# Altium schematic sheet led_matrix_board.SchDoc(led_matrix_board)
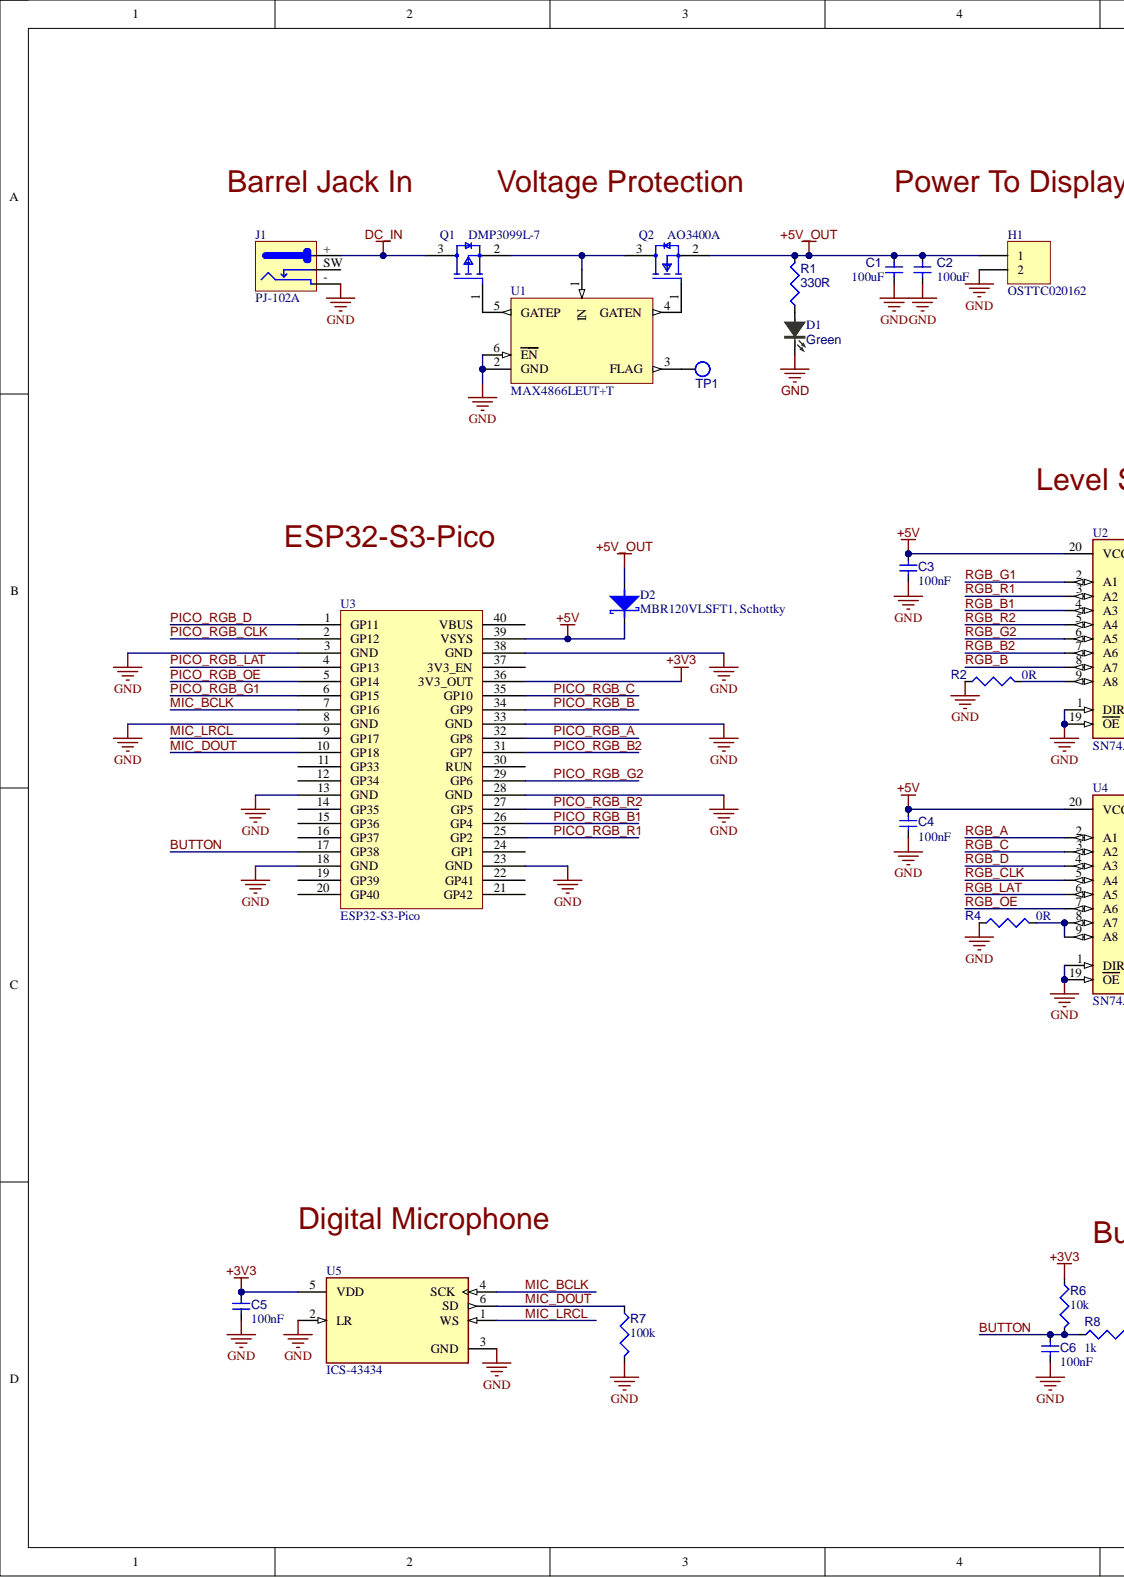
[diagram p0: led_matrix_board.SchDoc, left]
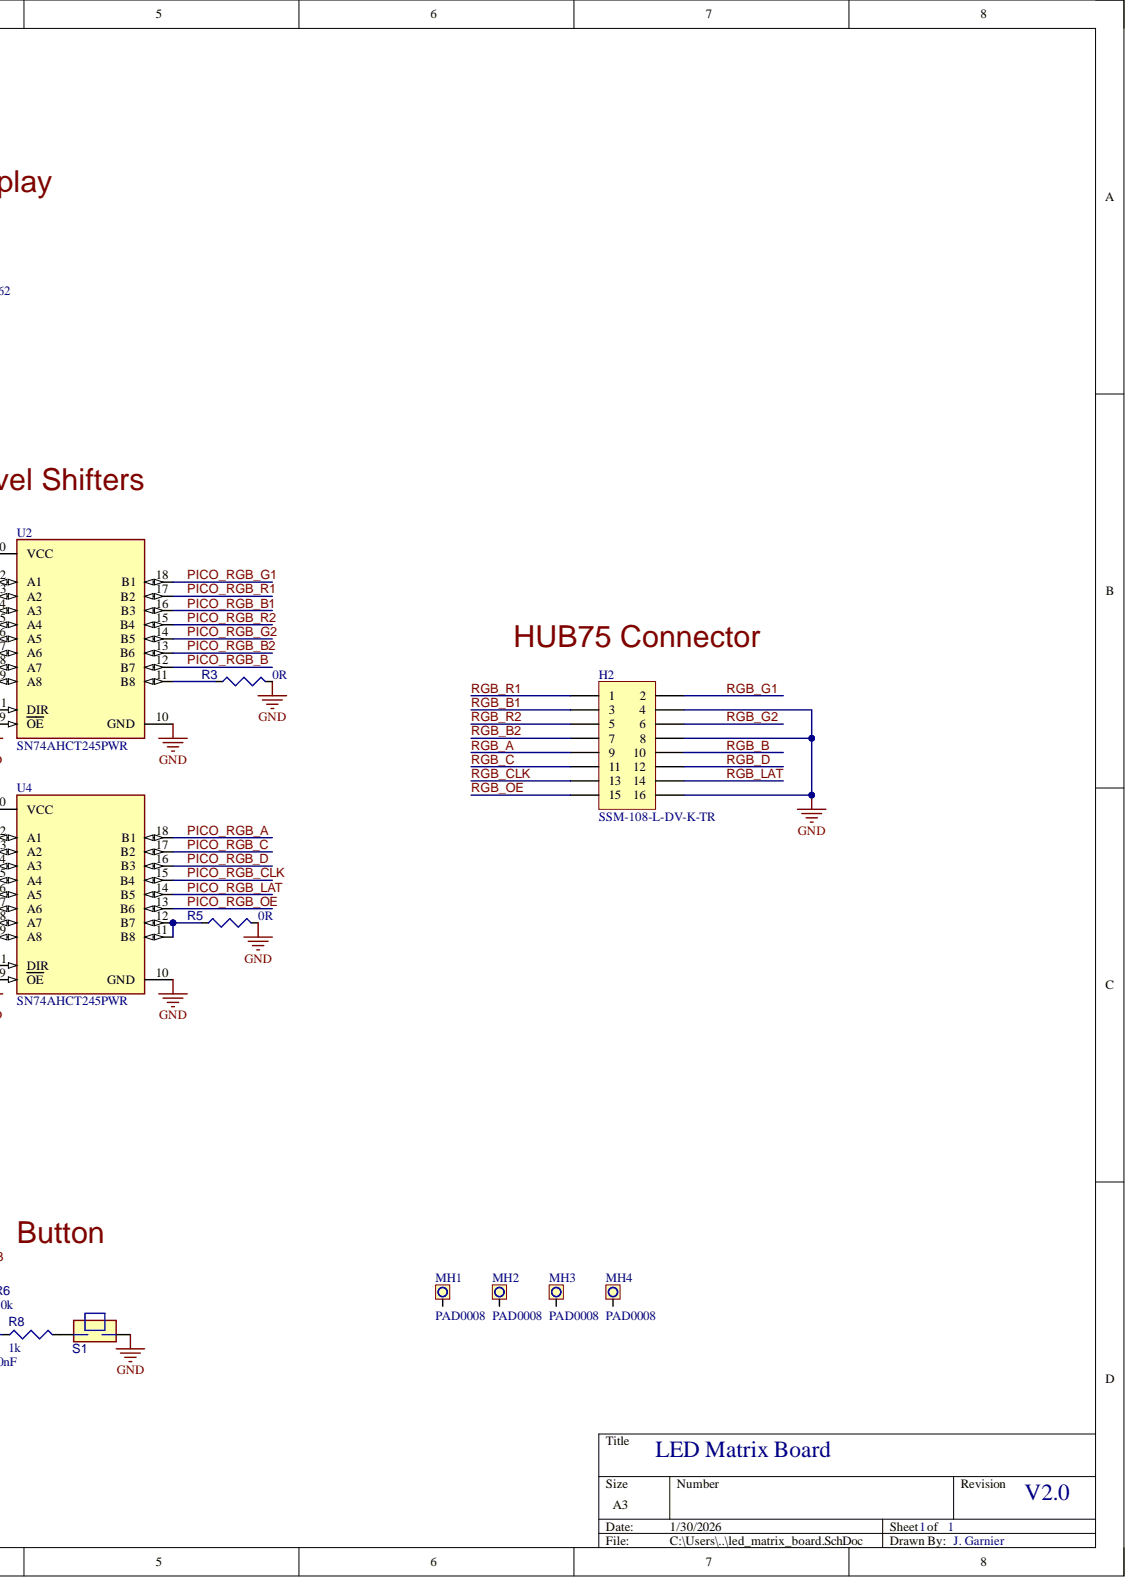
[diagram p1: led_matrix_board.SchDoc, right]

## Parts
C1  value 100uF  [CAPC3225X20N]  (hidden comment: CL32A107MPVNNNE)
C2  value 100uF  [CAPC3225X20N]  (hidden comment: CL32A107MPVNNNE)
C3  value 100nF  [0603_cap]  (hidden comment: C0603C104K8RACTU)
C4  value 100nF  [0603_cap]  (hidden comment: C0603C104K8RACTU)
C5  value 100nF  [0603_cap]  (hidden comment: C0603C104K8RACTU)
C6  value 100nF  [0603_cap]  (hidden comment: C0603C104K8RACTU)
D1  value Green  [LED_0402_GREEN]  (hidden comment: XZVGR68W-3)
D2  MBR120VLSFT1  value MBR120VLSFT1, Schottky  [SOD-123FL]
H1  OSTTC020162  [SCREW_TERM_2WAY_5MM]
H2  SSM-108-L-DV-K-TR  [FP-SSM-108-L-DV-K-TR-MFG]
J1  PJ-102A  [PJ-102A]
MH1  PAD0008  [MH_600_320_NPTH]
MH2  PAD0008  [MH_600_320_NPTH]
MH3  PAD0008  [MH_600_320_NPTH]
MH4  PAD0008  [MH_600_320_NPTH]
Q1  DMP3099L-7  [FP-SOT23-IPC_C]
Q2  AO3400A  [FP-SOT23-IPC_C]
R1  value 330R  [0402_res]  (hidden comment: MCR01MZPF3300)
R2  value 0R  [0603_res]  (hidden comment: ERJ-3GEY0R00V)
R3  value 0R  [0603_res]  (hidden comment: ERJ-3GEY0R00V)
R4  value 0R  [0603_res]  (hidden comment: ERJ-3GEY0R00V)
R5  value 0R  [0603_res]  (hidden comment: ERJ-3GEY0R00V)
R6  value 10k  [0603_res]  (hidden comment: 0603WAF1002T5E)
R7  value 100k  [0603_res]  (hidden comment: 0603WAF1003T5E)
R8  value 1k  [0603_res]  (hidden comment: ERA-3AEB102V)
S1  EVQ-PF206K  [EVQ-PF206K]  (value not drawn)
TP1  PAD0035  [TP_40_SMT]  (value not drawn)  (no BOM)
U1  MAX4866LEUT+T  [MAX4866LEUT+T-Footprint-1]
U2  SN74AHCT245PWR  [FP-PW0020A-IPC_A]
U3  ESP32-S3-Pico  [ESP32-S3-Pico]
U4  SN74AHCT245PWR  [FP-PW0020A-IPC_A]
U5  ICS-43434  [FP-ICS-43434-MFG]

## Nets
+3V3: C5.1 R6.1 U3.36(3V3_OUT) U5.5(VDD)
+5V: C3.1 C4.1 D2.K U2.20(VCC) U3.39(VSYS) U4.20(VCC)
+5V_OUT: C1.1 C2.1 D2.A H1.1 Q2.2 R1.2
DC_IN: J1.+ Q1.3
GND: C1.2 C2.2 C3.2 C4.2 C5.2 C6.2 D1.K H1.2 H2.4 H2.8 H2.16 J1.- R2.1 R3.1 R4.1 R5.2 R7.2 S1.2 U1.2(GND) U1.6(EN) U2.1(DIR) U2.10(GND) U2.19(OE) U3.3(GND) U3.8(GND) U3.13(GND) U3.18(GND) U3.23(GND) U3.28(GND) U3.33(GND) U3.38(GND) U4.1(DIR) U4.10(GND) U4.19(OE) U5.2(LR) U5.3(GND)
BUTTON: C6.1 R6.2 R8.1 U3.17(GP38)
MIC_BCLK: U3.7(GP16) U5.4(SCK)
MIC_DOUT: R7.1 U3.10(GP18) U5.6(SD)
MIC_LRCL: U3.9(GP17) U5.1(WS)
PICO_RGB_A: U3.32(GP8) U4.18(B1)
PICO_RGB_B: U2.12(B7) U3.34(GP9)
PICO_RGB_B1: U2.16(B3) U3.26(GP4)
PICO_RGB_B2: U2.13(B6) U3.31(GP7)
PICO_RGB_C: U3.35(GP10) U4.17(B2)
PICO_RGB_CLK: U3.2(GP12) U4.15(B4)
PICO_RGB_D: U3.1(GP11) U4.16(B3)
PICO_RGB_G1: U2.18(B1) U3.6(GP15)
PICO_RGB_G2: U2.14(B5) U3.29(GP6)
PICO_RGB_LAT: U3.4(GP13) U4.14(B5)
PICO_RGB_OE: U3.5(GP14) U4.13(B6)
PICO_RGB_R1: U2.17(B2) U3.25(GP2)
PICO_RGB_R2: U2.15(B4) U3.27(GP5)
RGB_A: H2.9 U4.2(A1)
RGB_B: H2.10 U2.8(A7)
RGB_B1: H2.3 U2.4(A3)
RGB_B2: H2.7 U2.7(A6)
RGB_C: H2.11 U4.3(A2)
RGB_CLK: H2.13 U4.5(A4)
RGB_D: H2.12 U4.4(A3)
RGB_G1: H2.2 U2.2(A1)
RGB_G2: H2.6 U2.6(A5)
RGB_LAT: H2.14 U4.6(A5)
RGB_OE: H2.15 U4.7(A6)
RGB_R1: H2.1 U2.3(A2)
RGB_R2: H2.5 U2.5(A4)
NetD1_A: D1.A R1.1
NetQ1_1: Q1.1 U1.5(GATEP)
NetQ1_2: Q1.2 Q2.3 U1.1(IN)
NetQ2_1: Q2.1 U1.4(GATEN)
NetR2_2: R2.2 U2.9(A8)
NetR3_2: R3.2 U2.11(B8)
NetR4_2: R4.2 U4.8(A7) U4.9(A8)
NetR5_1: R5.1 U4.11(B8) U4.12(B7)
NetR8_2: R8.2 S1.1
NetTP1_1: TP1.1 U1.3(FLAG)

Not connected: J1 SW; MH1 1; MH2 1; MH3 1; MH4 1; U3 11(GP33) 12(GP34) 14(GP35) 15(GP36) 16(GP37) 19(GP39) 20(GP40) 21(GP42) 22(GP41) 24(GP1) 30(RUN) 37(3V3_EN) 40(VBUS)

## Library data of the parts
C1 C2 = CAP0047-3: CAP CER 100UF 10V ±20% X5R 1210; Generic Allowed: Yes; Subtype: Ceramic; ClassName: CHIP_OTHER; Component Kind: Standard; Component Rating: X5R; Package: 1210; Tolerance: 20%; Voltage: 10V; Library Name: Morse Micro Pty Limited
C3-C6 = CAP0030-8: CAP CER 100NF 10V ±10% X7R 0603; Generic Allowed: Yes; Subtype: Ceramic; ClassName: CHIP_OTHER; Component Kind: Standard; Component Rating: X7R; Package: 0603; Tolerance: 10%; Voltage: 10V; Library Name: Morse Micro Pty Limited
D1 = DIO0053-6: LED GREEN 0402 SMD; Generic Allowed: Yes; Voltage: 2.0V; ClassName: CHIP_OTHER; Component Kind: Standard; Package: 0402; Library Name: Morse Micro Pty Limited
D2 = DIO0005-3: Diodes, MBR120VLSFT1 Schottky 1A 20V, Vf=0.34V; Generic Allowed: No; Value: MBR120VLSFT1, Schottky; ClassName: COMP_OTHER; Component Kind: Standard; Component Rating: Vf=0.34V; Current: 1A; Package: SOD-123FL; Voltage: 20V; Library Name: Morse Micro Pty Limited
H1 = JCK0020-1: CONN TERM BLOCK 5MM 2POS; Generic Allowed: Yes; ClassName: COMP_OTHER; Component Kind: Standard; Package: THRU-Hole; Library Name: Morse Micro Pty Limited
H2 = HDR0162-1: PCB Receptacle, Board-to-Board, 2.54 mm, 2 Rows, 16 Contacts, Surface Mount; Manufacturer Lifecycle Status: PRODUCTION; Connector Type: Header, Socket; Current Rating: 5.2A; Dielectric Material: Polyamide; Number of Contacts: 16; Pitch: 2.54mm; Voltage Rating: 572V; Color: Black; Component Kind: Standard; Contact Gender: Female; Contact Material: Gold, Nickel; Contact Plating: Gold; Gender: Receptacle; Height: 7.46mm; Housing Color: Black; Housing Material: Nylon; Lead Free: Lead Free; Length: 20.8mm; Lifecycle Status: Production; Max Operating Temperature: 125°C; Min Operating Temperature: -55°C; Mounting Technology: Surface Mount; Number of Positions: 16; Number of Rows: 2; Packaging: Tape and Reel; Pins: 16; REACH SVHC: No; RoHS: Compliant; Row Spacing: 2.54mm; Terminal Pitch: 2.54mm; Termination: Solder; Width: 5mm; Library Name: Morse Micro Pty Limited
J1 = JCK0011-1: Conn Power Jack 2.1mm PCB Mount Thru-Hole; Generic Allowed: No; ClassName: COMP_OTHER; Component Kind: Standard; Package: THRU-Hole; Library Name: Morse Micro Pty Limited
MH1-MH4 = PAD0008-2: MH 6.00MM DIA 3.2MM HOLE NPTH; Shape: Round; ClassName: PCB_PATTERN; Component Kind: Standard; Library Name: Morse Micro Pty Limited
Q1 = TSR0027-1: DMP3099L Series 30 V 65 mOhm P-Channel Enhancement Mode Mosfet - SOT-23-3; Continuous Drain Current (ID): 3.8A; Drain to Source Breakdown Voltage: -30V; Drain to Source Resistance: 65mΩ; Element Configuration: Single; Fall Time: 15ns; Gate to Source Voltage (Vgs): 20V; Input Capacitance: 563pF; Max Junction Temperature (Tj): 150°C; Max Power Dissipation: 1.08W; Min Breakdown Voltage: 30V; Number of Channels: 1; Rise Time: 5ns; Threshold Voltage: -2.1V; Turn-Off Delay Time: 31ns; Turn-On Delay Time: 4.8ns; Case/Package: SOT-23; China RoHS: Compliant; Component Kind: Standard; Contact Plating: Tin; Drain to Source Voltage (Vdss): -30V; Export Control Classification Number (ECCN) Code: EAR99; Height: 1.1mm; Introduction Date: 2013-05-28; Lead Free: Lead Free; Length: 3mm; Lifecycle Status: Production; Max Operating Temperature: 150°C; Min Operating Temperature: -55°C; Mounting Technology: Surface Mount; Number of Elements: 1; Number of Terminals: 3; Pins: 3; Power Dissipation: 1.08W; Radiation Hardening: No; Rds On Max: 65mΩ; REACH SVHC: Yes; RoHS: Compliant; Schedule B: 8541290080, 8541290080; Weight: 7.994566mg; Width: 1.4mm; Library Name: Morse Micro Pty Limited
Q2 = TSR0028-1: Trans MOSFET N-CH 30V 5.7A 3-Pin SOT-23; Drain to Source Resistance: 26.5mΩ; Gate to Source Voltage (Vgs): 12V; Input Capacitance: 630pF; Max Junction Temperature (Tj): 150°C; Max Power Dissipation: 1.4W; Number of Channels: 1; Threshold Voltage: 650mV; Turn-Off Delay Time: 25ns; Turn-On Delay Time: 3ns; Case/Package: SOT-23; Component Kind: Standard; Continuous Drain Current (ID): 5.7A; Drain to Source Breakdown Voltage: 30V; Drain to Source Voltage (Vdss): 30V; Export Control Classification Number (ECCN) Code: EAR99; Height: 1.25mm; Introduction Date: 2007-04-17; Lead Free: Lead Free; Lifecycle Status: Production; Max Operating Temperature: 150°C; Min Breakdown Voltage: 30V; Min Operating Temperature: -55°C; Mounting Technology: Surface Mount; Number of Elements: 1; Number of Terminals: 3; Pins: 3; Power Dissipation: 1.4W; Radiation Hardening: No; Rds On Max: 26.5mΩ; REACH SVHC: Yes; RoHS: Compliant; Schedule B: 8541290080, 8541290080; Library Name: Morse Micro Pty Limited
R1 = RES0073-11: RES SMD 330R OHM ±1% 1/16W 0402; Generic Allowed: Yes; ClassName: CHIP_MICRO; Component Kind: Standard; Package: 0402; Power: 1/16W; Tolerance: 1%; Library Name: Morse Micro Pty Limited
R2-R5 = RES0003-8: RES SMD 0R OHM ±1% 1/10W 0603; Generic Allowed: Yes; ClassName: CHIP_MICRO; Component Kind: Standard; Package: 0603; Power: 1/10W; Tolerance: 1%; Library Name: Morse Micro Pty Limited
R6 = RES0219-8: RES SMD 10K OHM ±1% 1/10W 0603; Generic Allowed: Yes; Subtype: Thick Film; ClassName: CHIP_MICRO; Component Kind: Standard; Package: 0603; Power: 1/10W; Tolerance: 1%; Library Name: Morse Micro Pty Limited
R7 = RES0224-8: RES SMD 100K OHM ±1% 1/10W 0603; Generic Allowed: Yes; Subtype: Thick Film; ClassName: CHIP_MICRO; Component Kind: Standard; Package: 0603; Power: 1/10W; Tolerance: 1%; Library Name: Morse Micro Pty Limited
R8 = RES0105-8: RES SMD 1K OHM ±0 .1% 1/10W 0603; Generic Allowed: Yes; Tolerance: 0 .1%; ClassName: CHIP_OTHER; Component Kind: Standard; Package: 0603; Power: 1/10W; Library Name: Morse Micro Pty Limited
S1 = SWH0003-1: SW, Tactile 15V@20mA, EVQ-PF, Through Hole, R/A, 7.5x7.1x5.85mm; Generic Allowed: Yes; ClassName: COMP_OTHER; Component Kind: Standard; Package: EVQ-PF206K; Library Name: Morse Micro Pty Limited
TP1 = PAD0035-2: 40mil 1mm SMD Test Point; Shape: Round; ClassName: PCB_PATTERN; Library Name: Morse Micro Pty Limited
U1 = ICT0297-2: Overvoltage Protection Controllers with Reverse Polarity Protection; Manufacturer Lifecycle Status: PRODUCTION; Max Junction Temperature (Tj): 150°C; Max Power Dissipation: 696mW; Max Supply Voltage: 28V; Min Supply Voltage: 1.2V; Nominal Supply Current: 77µA; Number of Channels: 1; Case/Package: SOT-23; Component Kind: Standard; Height: 1.45mm; Lifecycle Status: Production; Max Operating Temperature: 85°C; Min Operating Temperature: -40°C; Mounting Technology: Surface Mount; Number of Outputs: 1; Pins: 6; Radiation Hardening: No; RoHS: Compliant; Library Name: Morse Micro Pty Limited
U2 U4 = ICT0294-1: 8mA 8 4.5V~5.5V 8mA 1 TSSOP-20 Buffer/Driver/Transceiver ROHS; Manufacturer Lifecycle Status: ACTIVE; Direction: Bidirectional; High Level Output Current: -8mA; Logic Function: Inverting, Transceiver; Max Supply Voltage: 5.5V; Min Supply Voltage: 4.5V; Nominal Supply Current: 4µA; Number of Bits: 8; Number of Circuits: 1; Operating Supply Voltage: 5V; Polarity: Non-Inverting; Propagation Delay: 5.3ns; Turn-On Delay Time: 16.5ns; Ambient Temperature Range High: 125°C; Case/Package: TSSOP; Component Kind: Standard; Contact Plating: Gold; Height: 0m; Lead Free: Lead Free; Length: 6.5mm; Lifecycle Status: Production; Low Level Output Current: 8mA; Max Operating Temperature: 125°C; Min Operating Temperature: -40°C; Mounting Technology: Surface Mount; Number of Bits per Element: 8; Number of Channels: 8; Number of Elements: 1; Number of Inputs: 8; Number of Outputs: 8; Pins: 20; Quiescent Current: 4µA; Radiation Hardening: No; REACH SVHC: No; RoHS: Compliant; Schedule B: 8542390000, 8542390000; Thickness: 1mm; Weight: 76.997305mg; Width: 4.4mm; Library Name: Morse Micro Pty Limited
U3 = MOD0041-1: ESP32-S3-Pico Module.; Component Kind: Standard; Library Name: Morse Micro Pty Limited
U5 = CMP-00035-1: Mic Omni-Directional -26dB 3.63V Rectangular Solder Pad T/R; Direction: Omnidirectional; Min Supply Voltage: 1.65V; Operating Supply Current: 550µA; Output Type: Digital; Component Kind: Standard; Height: 980µm; Length: 3.5mm; Lifecycle Status: Production; Max Length: 3.6mm; Max Operating Temperature: 85°C; Max Supply Voltage: 3.63V; Max Thickness: 1.08mm; Max Width: 2.75mm; Min Length: 3.4mm; Min Operating Temperature: -40°C; Min Thickness: 880µm; Min Width: 2.55mm; RoHS: Compliant; Schedule B: 8518100000, 8518100000; Width: 2.65mm; Library Name: Morse Micro Pty Limited
